# Altium schematic sheet external.SchDoc
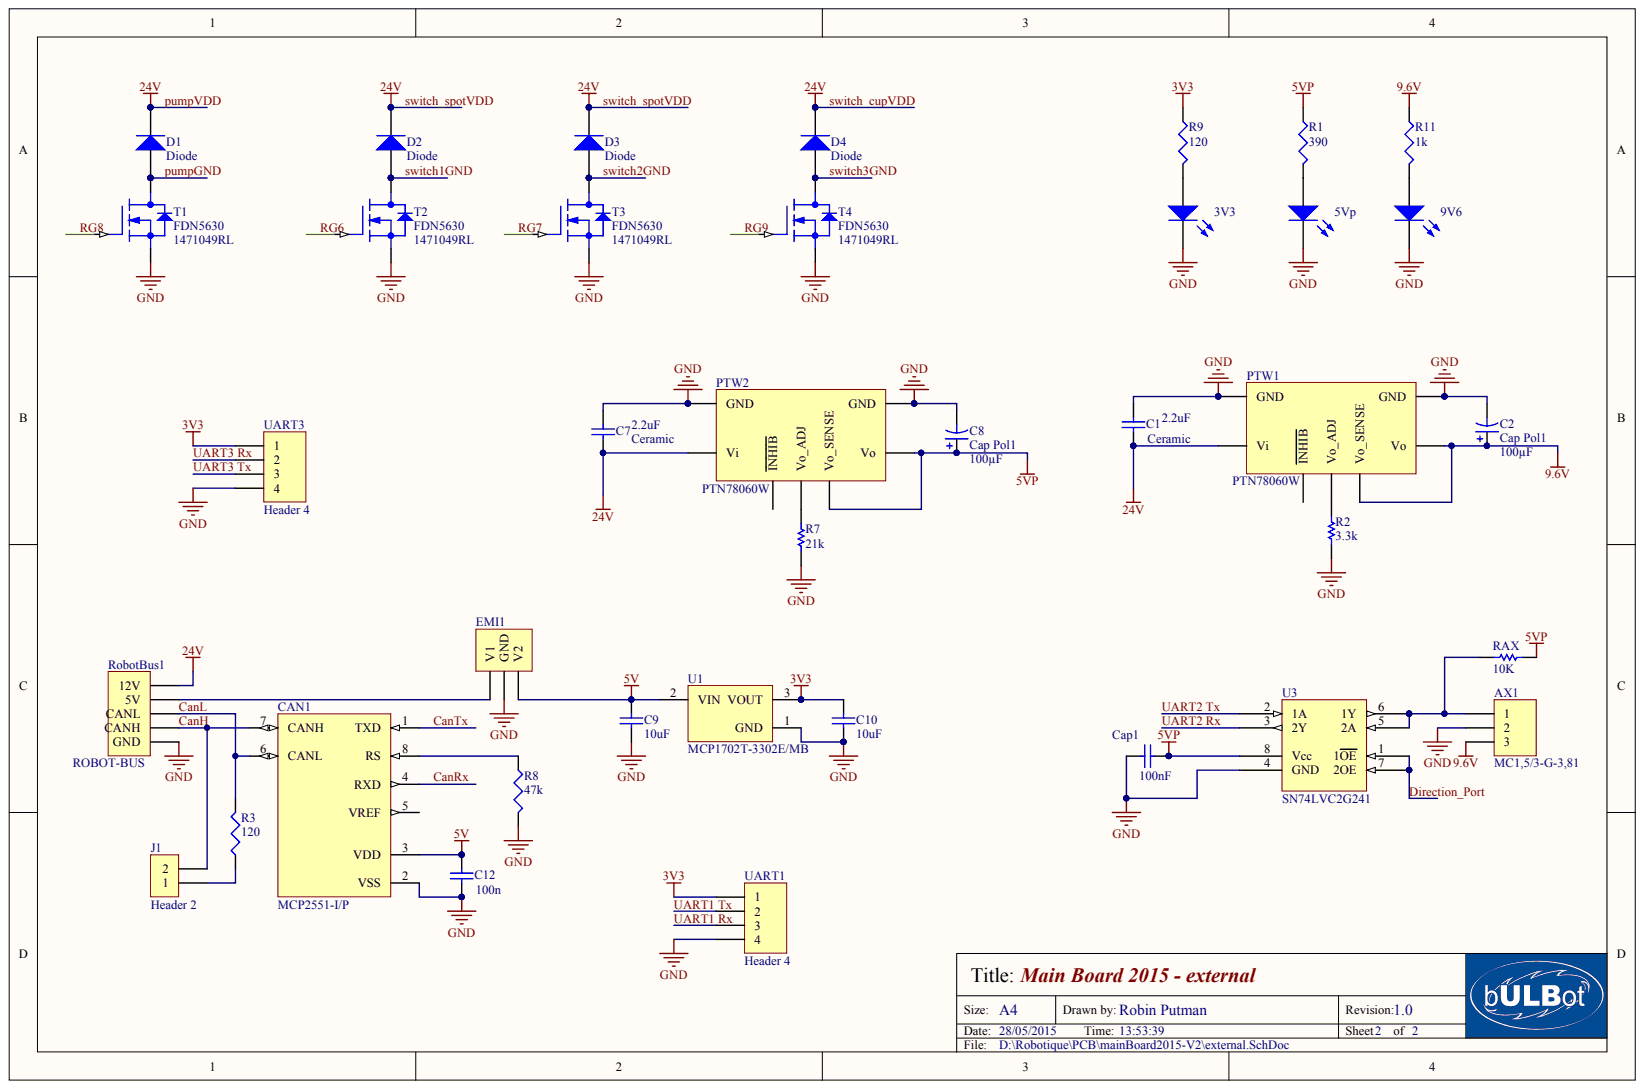
[diagram p0: external.SchDoc]

## Parts
3V3  LED  (value not drawn)
5Vp  LED  (value not drawn)
9V6  LED  (value not drawn)
AX1  MC1,5/3-G-3,81
C1  value 2.2uF  (hidden comment: Cap)
C2  Cap Pol1  value 100µF
C7  value 2.2uF  (hidden comment: Cap)
C8  Cap Pol1  value 100µF
C9  value 10uF  (hidden comment: Cap)
C10  value 10uF  (hidden comment: Cap)
C12  value 100n  (hidden comment: Cap Semi)
CAN1  MCP2551-I/P
Cap1  Cap  value 100nF
D1  Diode
D2  Diode
D3  Diode
D4  Diode
EMI1  EMI Filter  (value not drawn)
J1  Header 2
PTW1  PTN78060W
PTW2  PTN78060W
R1  value 390  (hidden comment: Res3)
R2  value 3.3k  (hidden comment: Resistance)
R3  value 120  (hidden comment: Res3)
R7  value 21k  (hidden comment: Resistance)
R8  value 47k  (hidden comment: Res3)
R9  value 120  (hidden comment: Res3)
R11  value 1k  (hidden comment: Res3)
RAX  value 10K  (hidden comment: Resistance)
RobotBus1  ROBOT-BUS
T1  FDN5630  Supplier Part Number 1: 1471049RL
T2  FDN5630  Supplier Part Number 1: 1471049RL
T3  FDN5630  Supplier Part Number 1: 1471049RL
T4  FDN5630  Supplier Part Number 1: 1471049RL
U1  MCP1702T-3302E/MB
U3  SN74LVC2G241
UART1  Header 4
UART3  Header 4

## Nets
3V3: C10.1 R9.1 U1.3 UART1.1 UART3.1
5V: C9.1 C12.2 CAN1.3(VDD) EMI1.3 U1.2
5VP: C8.1 Cap1.1 PTW2.5(Vo_SENSE) PTW2.6(Vo) R1.1 RAX.1 U3.8(Vcc)
9.6V: AX1.3 C2.1 PTW1.5(Vo_SENSE) PTW1.6(Vo) R11.1
GND: 3V3.2 5Vp.2 9V6.2 AX1.2 C1.2 C2.2 C7.2 C8.2 C9.2 C10.2 C12.1 CAN1.2(VSS) Cap1.2 EMI1.2 PTW1.1(GND) PTW1.7(GND) PTW2.1(GND) PTW2.7(GND) R2.2 R7.2 R8.2 RobotBus1.5(GND) T1.2 T2.2 T3.2 T4.2 U1.1 U3.4(GND) UART1.4 UART3.4
CANH: CAN1.7(CANH) J1.2 RobotBus1.4
CANL: CAN1.6(CANL) R3.2 RobotBus1.3(CANL)
CANRX: CAN1.4(RXD)
CANTX: CAN1.1(TXD)
DIRECTION_PORT: U3.1(1OE) U3.7(2OE)
PUMPGND: D1.1 T1.3
PUMPVDD: C1.1 C7.1 D1.2 D2.2 D3.2 D4.2 PTW1.2(Vi) PTW2.2(Vi) RobotBus1.1(12V)
RG6: T2.1
RG7: T3.1
RG8: T1.1
RG9: T4.1
SWITCH1GND: D2.1 T2.3
SWITCH2GND: D3.1 T3.3
SWITCH3GND: D4.1 T4.3
UART1 RX: UART1.3
UART1 TX: UART1.2
UART2 RX: U3.3(2Y)
UART2 TX: U3.2(1A)
UART3 RX: UART3.2
UART3 TX: UART3.3
Net3V3_1: 3V3.1 R9.2
Net5Vp_1: 5Vp.1 R1.2
Net9V6_1: 9V6.1 R11.2
NetAX1_1: AX1.1 RAX.2 U3.5(2A) U3.6(1Y)
NetCAN1_8: CAN1.8(RS) R8.1
NetEMI1_1: EMI1.1 RobotBus1.2(5V)
NetJ1_1: J1.1 R3.1
NetPTW1_4: PTW1.4(Vo_ADJ) R2.1
NetPTW2_4: PTW2.4(Vo_ADJ) R7.1

Not connected: CAN1 5(VREF); PTW1 3(INHIB); PTW2 3(INHIB)

## Library data of the parts
CAN1 U1 = (no symbol name): Manufacturer: Microchip; PackageVersion: revBB, Aug-2009; Supplier 1: Digi-Key; ComponentLink1Description: Manufacturer URL; ComponentLink1URL: http://www.microchip.com/; ComponentLink2Description: Datasheet; ComponentLink3Description: Package Specification; ComponentLink3URL: http://www.microchip.com/stellent/groups/techpub_sg/documents/packagingspec/en012702.pdf
  CAN1: DatasheetVersion: revF, Jul-2010; PackageReference: PDIP300-P8; PackageDescription: 8-Lead Plastic Dual In-Line (P) - 300 mil Body [PDIP]; Supplier Part Number 1: MCP2551-I/P-ND; Supplier 2: Farnell; Supplier Part Number 2: 1292240; Supplier 3: Newark; Supplier Part Number 3: 61K2947; Supplier 4: Mouser; Supplier Part Number 4: 579-MCP2551-I/P; Mounting Technology: Through Hole; Code_JEDEC: MS-001; PartNumber: MCP2551-I/P; ComponentLink2URL: http://ww1.microchip.com/downloads/en/DeviceDoc/21667F.pdf
  U1: DatasheetVersion: revD, Jun-2009; PackageReference: SOT-89-MB3; PackageDescription: 3-Lead Plastic Small Outline Transistor Header (MB) [SOT-89]; Supplier Part Number 1: MCP1702T-3302E/MBTR-ND; Supplier 2: Digi-Key; Supplier Part Number 2: MCP1702T-3302E/MBCT-ND; Supplier 3: Digi-Key; Supplier Part Number 3: MCP1702T-3302E/MBDKR-ND; Supplier 4: Farnell; Supplier Part Number 4: 1605558RL; Supplier 5: Farnell; Supplier Part Number 5: 1605558; Supplier 6: Newark; Supplier Part Number 6: 11N7989; Supplier 7: Newark; Supplier Part Number 7: 56K6993; Supplier 8: Mouser; Supplier Part Number 8: 579-MCP1702T3302E/MB; Mounting Technology: Surface Mount; Code_IPC: SOT89; PartNumber: MCP1702T-3302E/MB; ComponentLink2URL: http://ww1.microchip.com/downloads/en/DeviceDoc/22008d.pdf
3V3 5Vp 9V6 AX1 C1 C2 C7-C10 C12 Cap1 D1-D4 EMI1 J1 PTW1 PTW2 R1-R3 R7-R9 R11 RAX RobotBus1 T1-T4 U3 UART1 UART3 = (no symbol name)
  3V3 5Vp 9V6: Published: 8-Jun-2000; LatestRevisionDate: 17-Jul-2002; LatestRevisionNote: Re-released for DXP Platform.; PackageVersion: Feb-2002; PackageReference: 3.2X1.6X1.1; Farnell code: KPT-2012EC
  AX1: LatestRevisionDate: 08-jun-2011; Farnell link: http://be.farnell.com/phoenix-contact/mc-1-5-3-g-3-81/header-right-angle-3-81mm-3way/dp/3704737?Ntt=3704737
  C1 C7: Published: 8-Jun-2000; LatestRevisionDate: 17-Jul-2002; LatestRevisionNote: Re-released for DXP Platform.; PackageReference: RAD-0.3
  C2 C8: Published: 8-Jun-2000; LatestRevisionDate: 17-Jul-2002; LatestRevisionNote: Re-released for DXP Platform.; PackageReference: RB7.6-15; PackageDescription: Polarized Capacitor (Radial); 2 Leads
  C9 C10 Cap1: Published: 8-Jun-2000; LatestRevisionDate: 17-Jul-2002; LatestRevisionNote: Re-released for DXP Platform.; PackageReference: RAD-0.3; PackageDescription: Radial Cap, Thru-Hole; 2 Leads; 0.3 in Pin Spacing
  C12: Published: 8-Jun-2000; LatestRevisionDate: 29-May-2009; LatestRevisionNote: IPC-7351 Footprint Added.; PackageReference: C1206; PackageDescription: Chip Capacitor
  D1-D4: Published: 8-Jun-2000; LatestRevisionDate: 17-Jul-2002; LatestRevisionNote: Re-released for DXP Platform.; DatasheetVersion: 1997; PackageReference: DO-35; PackageDescription: Diode, Axial; Body 3.8 x 1.9 mm (LxDia. max), Lead Dia. 0.53 mm (max); Code_JEDEC: DO-204-AH; ComponentLink1Description: Manufacturer Link; ComponentLink1URL: http://www.fairchildsemi.com/; ComponentLink2Description: Datasheet; ComponentLink2URL: http://www.fairchildsemi.com/ds/1N%2F1N4148.pdf
  EMI1 J1 UART1 UART3: LatestRevisionDate: 17-Jul-2002; LatestRevisionNote: Re-released for DXP Platform.
  PTW1 PTW2: Supplier 1: Farnell; Supplier Part Number 1: 1262368
  R1 R3 R8 R9 R11: Published: 8-Jun-2000; LatestRevisionDate: 29-May-2009; LatestRevisionNote: IPC-7351 Footprint Added.; PackageReference: J1-0603; PackageDescription: Chip Resistor
  R2 R7 RAX: Published: 8-Jun-2000; LatestRevisionDate: 17-Jul-2002; LatestRevisionNote: Re-released for DXP Platform.; PackageReference: AXIAL-0.5
  RobotBus1: LatestRevisionDate: 08-jun-2011; Farnell link: http://be.farnell.com/phoenix-contact/mc-1-5-5-g-3-81/header-right-angle-3-81mm-5way/dp/3704750?Ntt=3704750
  T1-T4: Supplier 1: Farnell
  U3: Supplier 1: Farnell; Supplier Part Number 1: 2371311
  3V3 5Vp 9V6 C1 C2 C7-C10 C12 Cap1 D1-D4 EMI1 J1 R1-R3 R7-R9 R11 RAX UART1 UART3: Publisher: Altium Limited
  AX1 RobotBus1: Publisher: MO
